# Altium schematic sheet 3.5'' RPi LCD-luckfox.SchDoc
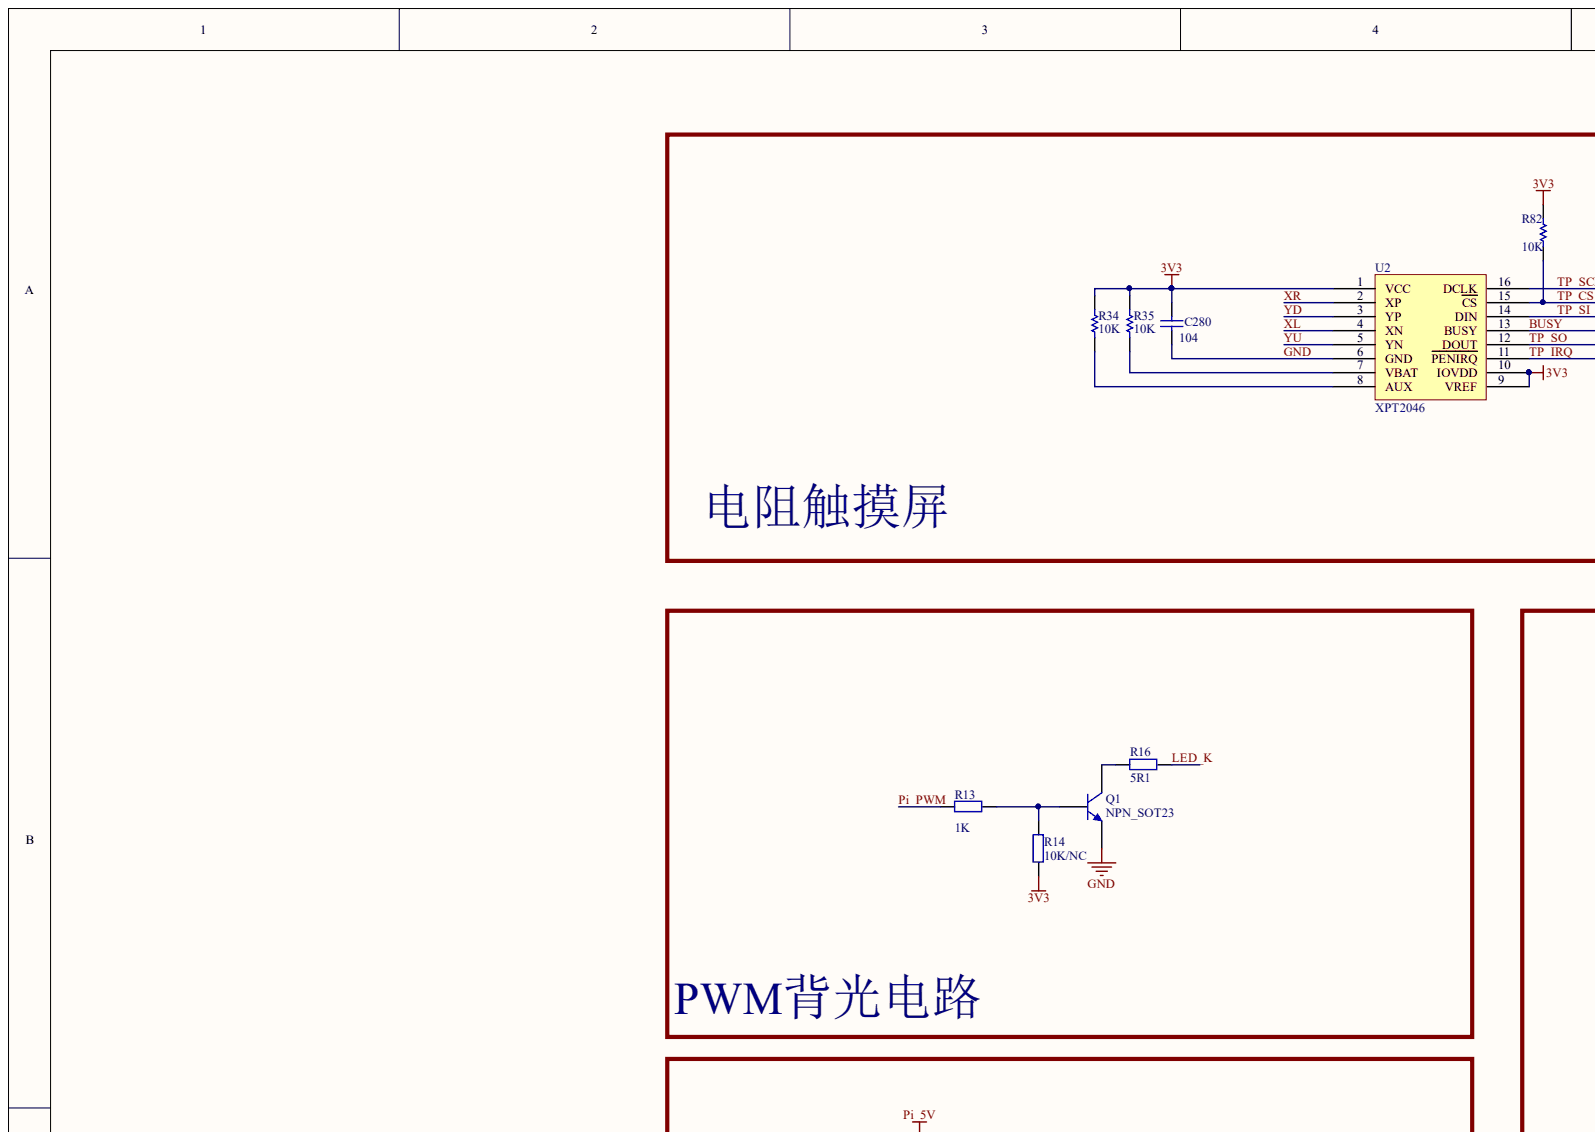
[diagram p0: 3.5'' RPi LCD-luckfox.SchDoc, top left]
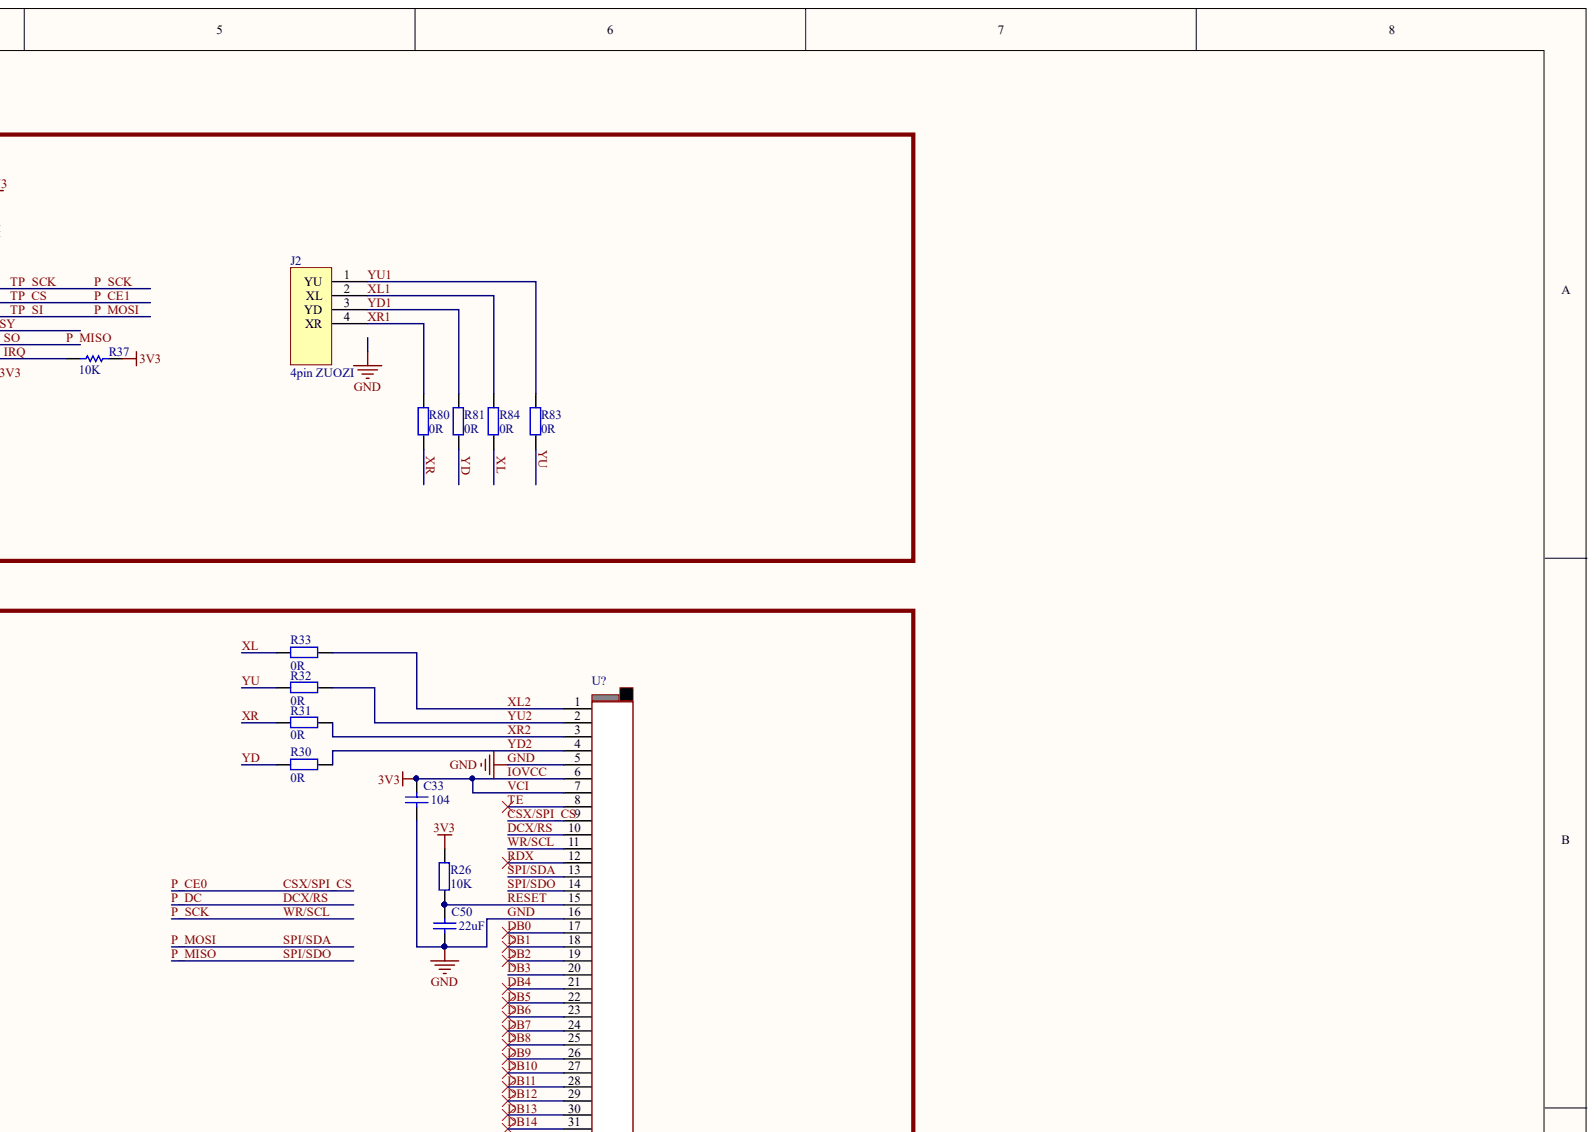
[diagram p1: 3.5'' RPi LCD-luckfox.SchDoc, top right]
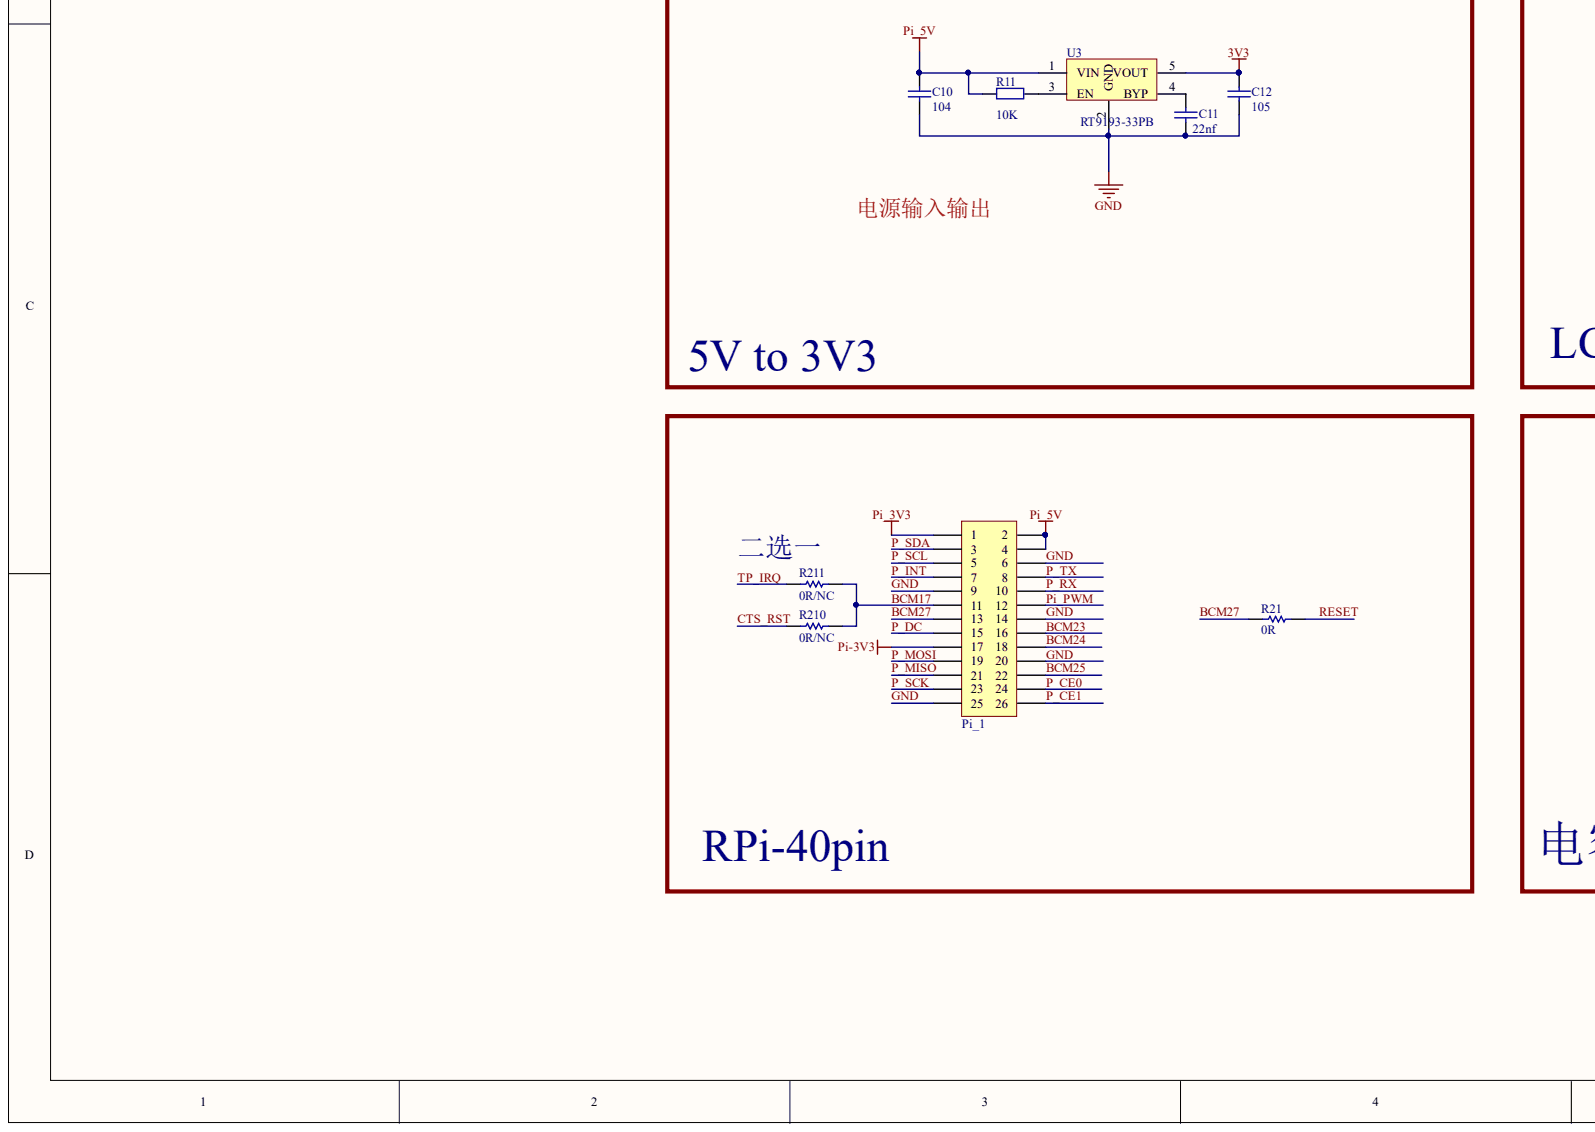
[diagram p2: 3.5'' RPi LCD-luckfox.SchDoc, bottom left]
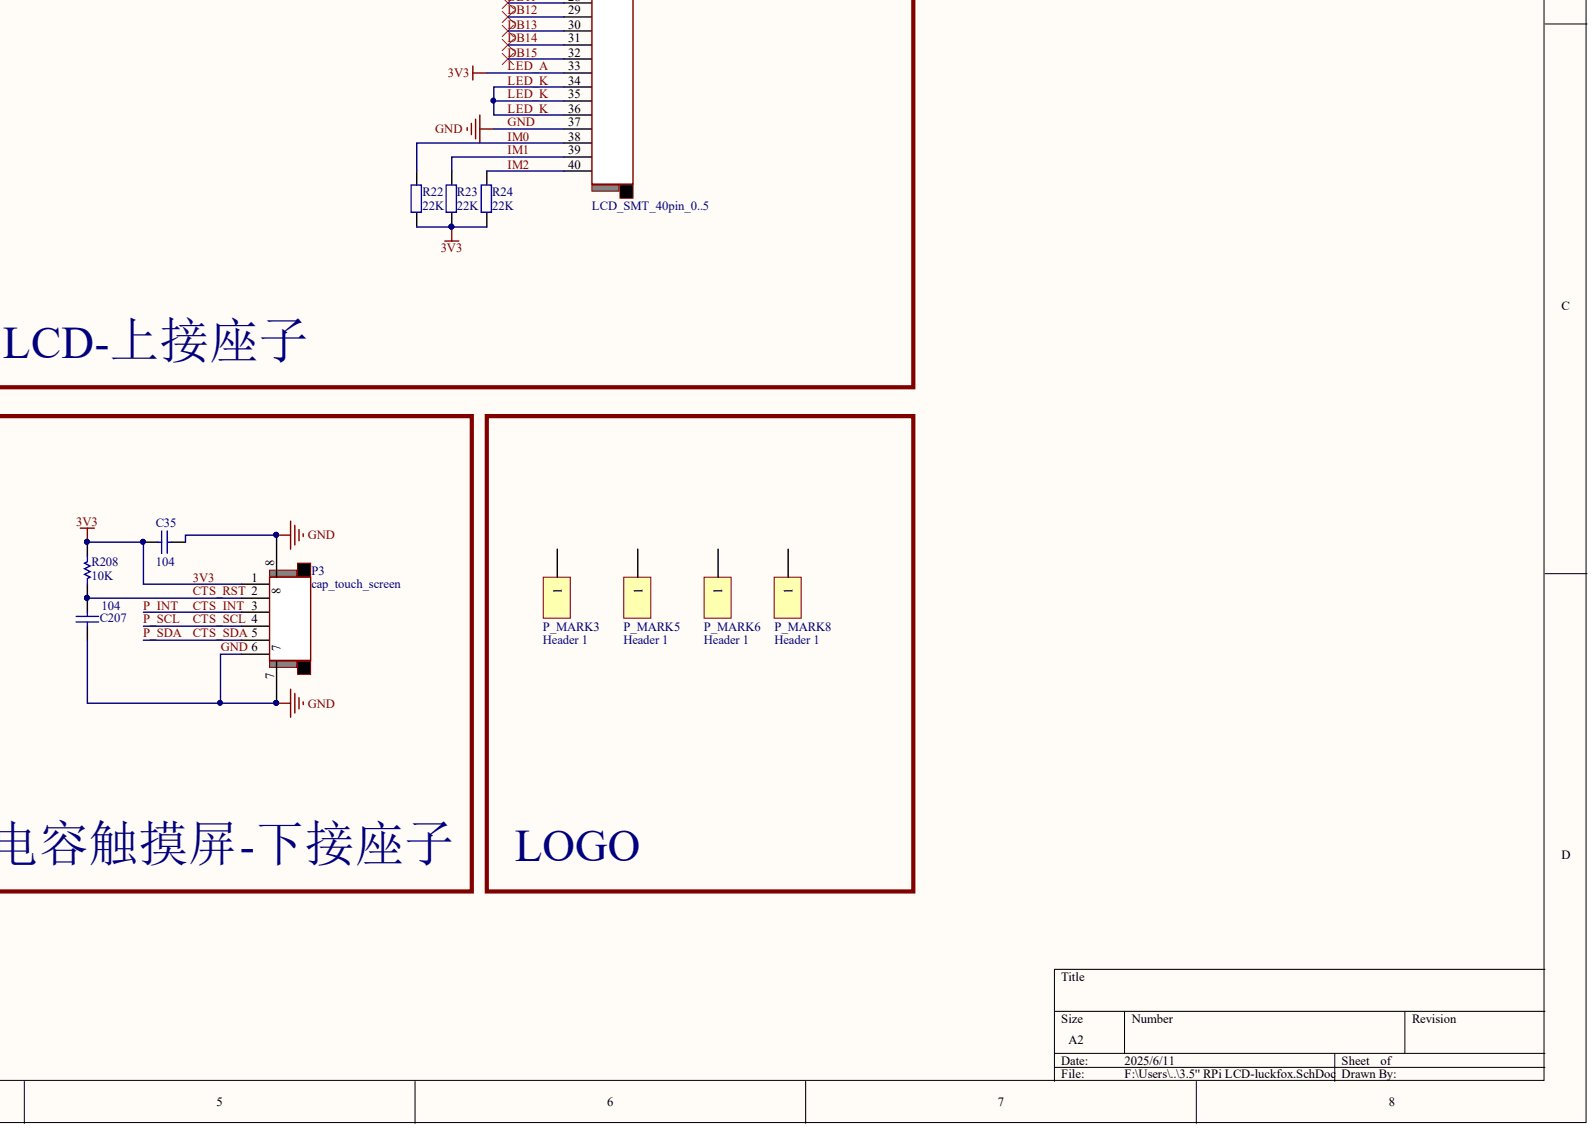
[diagram p3: 3.5'' RPi LCD-luckfox.SchDoc, bottom right]

## Parts
C10  104  [C0603]
C11  22nf  [C0603]
C12  105  [C0603]
C33  104  [C0603]
C35  104  [CAP-0603]
C50  22uF  [C0603]
C207  104  [CAP-0603]
C280  104  [C0603]
H_Pi?  Pi_1  [Header 13X2]
J2  4pin ZUOZI  [4pin 0.7]
P3  cap_touch_screen  [CTS_SMT_0.5mm_6pin]
P_MARK3  Header 1  [hole-1]
P_MARK5  Header 1  [hole-1]
P_MARK6  Header 1  [hole-1]
P_MARK8  Header 1  [hole-1]
Q1  NPN_SOT23  [SOT-23-3]
R11  value 10K  [R0603]  (hidden comment: Res2)
R13  value 1K  [R0603]  (hidden comment: Res2)
R14  value 10K/NC  [R0603]  (hidden comment: Res2)
R16  value 5R1  [R0603]  (hidden comment: Res2)
R21  0R  [0603]
R22  22K  [0603]
R23  22K  [0603]
R24  22K  [0603]
R26  10K  [0603]
R30  0R  [0603]
R31  0R  [0603]
R32  0R  [0603]
R33  0R  [0603]
R34  10K  [0603]
R35  10K  [0603]
R37  10K  [0603]
R80  0R  [0603]
R81  0R  [0603]
R82  10K  [0603]
R83  0R  [0603]
R84  0R  [0603]
R208  10K  [0603]
R210  0R/NC  [0603]
R211  0R/NC  [0603]
U2  XPT2046  [TSOP16]
U3  RT9193-33PB  [TPS61040]
U?  LCD_SMT_40pin_0..5  [FFC-40PIN]

## Nets
PI-3V3: H_Pi?.17
PI_3V3: H_Pi?.1
PI_5V: C10.1 H_Pi?.2 H_Pi?.4 R11.2 U3.1(VIN)
3V3: C12.1 C33.2 C35.1 C280.1 P3.1 R14.2 R22.2 R23.2 R24.2 R26.1 R34.1 R35.1 R37.1 R82.1 R208.2 U2.1(VCC) U2.9(VREF) U2.10(IOVDD) U3.5(VOUT) U?.6 U?.7 U?.33
BCM17: H_Pi?.11 R210.1 R211.1
BCM23: H_Pi?.16
BCM24: H_Pi?.18
BCM25: H_Pi?.22
BCM27: H_Pi?.13 R21.1
BUSY: U2.13(BUSY)
CSX/SPI_CS: H_Pi?.24 U?.9
CTS_INT: H_Pi?.7 P3.3
CTS_RST: C207.1 P3.2 R208.1 R210.2
CTS_SCL: H_Pi?.5 P3.4
CTS_SDA: H_Pi?.3 P3.5
DB0: U?.17
DB1: U?.18
DB2: U?.19
DB3: U?.20
DB4: U?.21
DB5: U?.22
DB6: U?.23
DB7: U?.24
DB8: U?.25
DB9: U?.26
DB10: U?.27
DB11: U?.28
DB12: U?.29
DB13: U?.30
DB14: U?.31
DB15: U?.32
DCX/RS: H_Pi?.15 U?.10
GND: C10.2 C11.2 C12.2 C33.1 C35.2 C50.1 C207.2 C280.2 H_Pi?.6 H_Pi?.9 H_Pi?.14 H_Pi?.20 H_Pi?.25 P3.6 P3.7 P3.8 Q1.2 U2.6(GND) U3.2(GND) U?.5 U?.16 U?.37 U?.41 U?.42
IM0: R22.1 U?.38
IM1: R23.1 U?.39
IM2: R24.1 U?.40
LED_K: R16.1 U?.34 U?.35 U?.36
P_CE1: H_Pi?.26 R82.2 U2.15(CS)
P_MISO: H_Pi?.21 U2.12(DOUT) U?.14
P_MOSI: H_Pi?.19 U2.14(DIN) U?.13
P_RX: H_Pi?.10
P_SCK: H_Pi?.23 U2.16(DCLK) U?.11
P_TX: H_Pi?.8
PI_PWM: H_Pi?.12 R13.2
RDX: U?.12
RESET: C50.2 R21.2 R26.2 U?.15
TE: U?.8
TP_IRQ: R37.2 R211.2 U2.11(PENIRQ)
XL: R33.2 R84.2 U2.4(XN)
XL1: J2.2(XL) R84.1
XL2: R33.1 U?.1
XR: R31.2 R80.2 U2.2(XP)
XR1: J2.4(XR) R80.1
XR2: R31.1 U?.3
YD: R30.2 R81.2 U2.3(YP)
YD1: J2.3(YD) R81.1
YD2: R30.1 U?.4
YU: R32.2 R83.2 U2.5(YN)
YU1: J2.1(YU) R83.1
YU2: R32.1 U?.2
NetC11_1: C11.1 U3.4(BYP)
NetQ1_1: Q1.1 R13.1 R14.1
NetQ1_3: Q1.3 R16.2
NetR11_1: R11.1 U3.3(EN)
NetR34_2: R34.2 U2.8(AUX)
NetR35_2: R35.2 U2.7(VBAT)

Not connected: P_MARK3 1; P_MARK5 1; P_MARK6 1; P_MARK8 1

## Library data of the parts
C10 C33 C35 C50 C207 C280 = Cap: Capacitor; Component Kind: Standard
  C10 C35 C207 C280: Library Name: zz.SchLib; Value: 104
  C33: LatestRevisionDate: 17-Jul-2002; LatestRevisionNote: Re-released for DXP Platform.; Library Name: Miscellaneous Devices.IntLib; PackageReference: RAD-0.3; Published: 8-Jun-2000; Value: 104
  C50: LatestRevisionDate: 17-Jul-2002; LatestRevisionNote: Re-released for DXP Platform.; Library Name: Miscellaneous Devices.IntLib; PackageReference: RAD-0.3; Published: 8-Jun-2000; Value: 22uF
  C33 C50: Publisher: Altium Limited
C11 C12 = Cap: Capacitor; Value: 104; Component Kind: Standard; Library Name: zz.SchLib
H_Pi? = Header 13X2: Header, 13-Pin; Component Kind: Standard; LatestRevisionDate: 17-Jul-2002; LatestRevisionNote: Re-released for DXP Platform.; Library Name: zz.SchLib; Publisher: Altium Limited
J2 = 4pin ZZ: Component Kind: Standard; Library Name: zz.SchLib
P_MARK3 P_MARK5 P_MARK6 P_MARK8 = Header 1: Header, 2-Pin; Component Kind: Standard; LatestRevisionDate: 17-Jul-2002; LatestRevisionNote: Re-released for DXP Platform.; Library Name: zz.SchLib; Publisher: Altium Limited
R11 R13 R14 R16 R22-R24 R26 R30-R33 R80 R81 R83 R84 = Res2: Resistor; Component Kind: Standard; LatestRevisionDate: 17-Jul-2002; LatestRevisionNote: Re-released for DXP Platform.; Library Name: Miscellaneous Devices.IntLib; PackageReference: AXIAL-0.4; Published: 8-Jun-2000; Publisher: Altium Limited
  R11 R13 R14 R16: PackageDescription: Axial Device, Thru-Hole; 2 Leads; 0.4 in Pin Spacing
  R22-R24: Value: 22K
  R26: Value: 10K
  R30-R33 R80 R81 R83 R84: Value: 0R
R21 R210 R211 = Res1: Resistor; Value: 10K; Component Kind: Standard; LatestRevisionDate: 17-Jul-2002; LatestRevisionNote: Re-released for DXP Platform.; Library Name: zz.SchLib; PackageReference: AXIAL-0.3; Published: 8-Jun-2000; Publisher: Altium Limited
R34 R35 R82 R208 = Res1: Resistor; Component Kind: Standard; LatestRevisionDate: 17-Jul-2002; LatestRevisionNote: Re-released for DXP Platform.; Library Name: zz.SchLib; PackageReference: AXIAL-0.3; Published: 8-Jun-2000; Value: 10K; Publisher: Altium Limited
R37 = Res1: Resistor; Value: 50k; Component Kind: Standard; LatestRevisionDate: 17-Jul-2002; LatestRevisionNote: Re-released for DXP Platform.; Library Name: zz.SchLib; PackageReference: AXIAL-0.3; Published: 8-Jun-2000; Publisher: Altium Limited
U3 = CAT6219: Component Kind: Standard; Library Name: zz.SchLib
P3 = cap_touch_screen: Component Kind: Standard; Library Name: zz.SchLib
Q1 = NPN_SOT23: Component Kind: Standard; Library Name: zz.SchLib
U2 = XPT2046: Component Kind: Standard; Library Name: zz.SchLib
U? = LCD_SMT_40pin_0..5: Component Kind: Standard; Library Name: zz.SchLib
